# Altium schematic sheet Master_controler.SchDoc
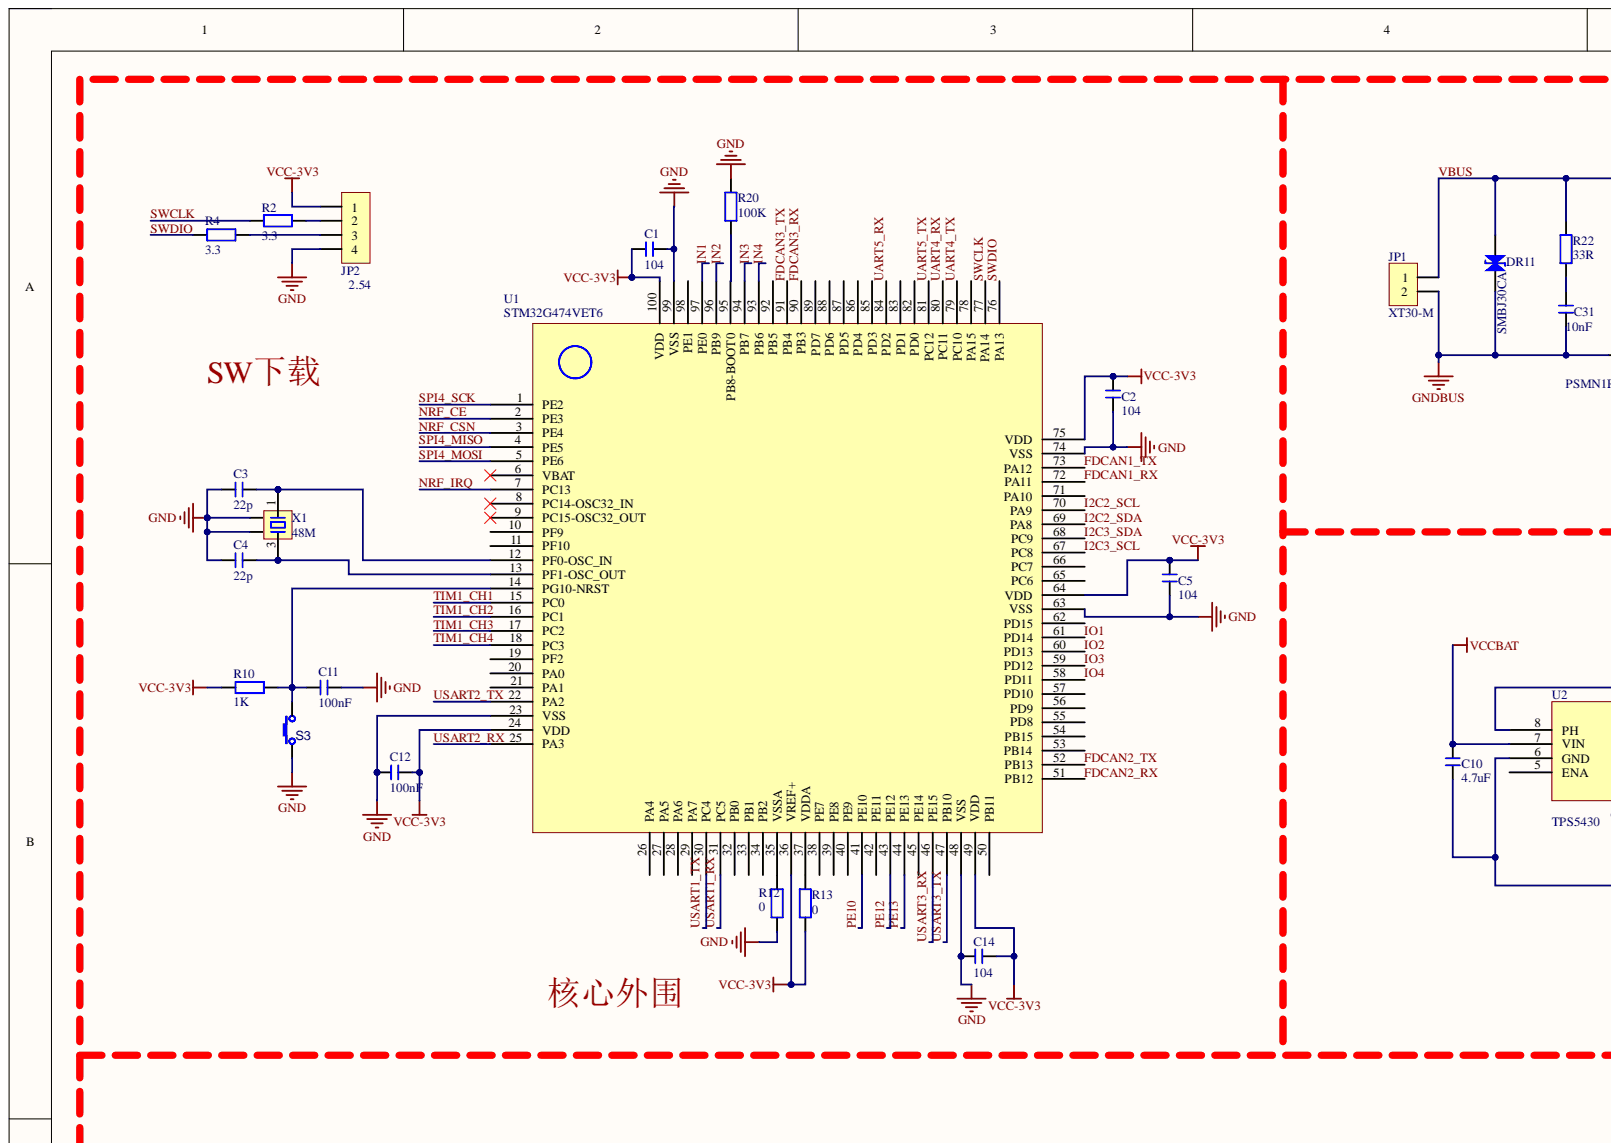
[diagram p0: Master_controler.SchDoc, top left]
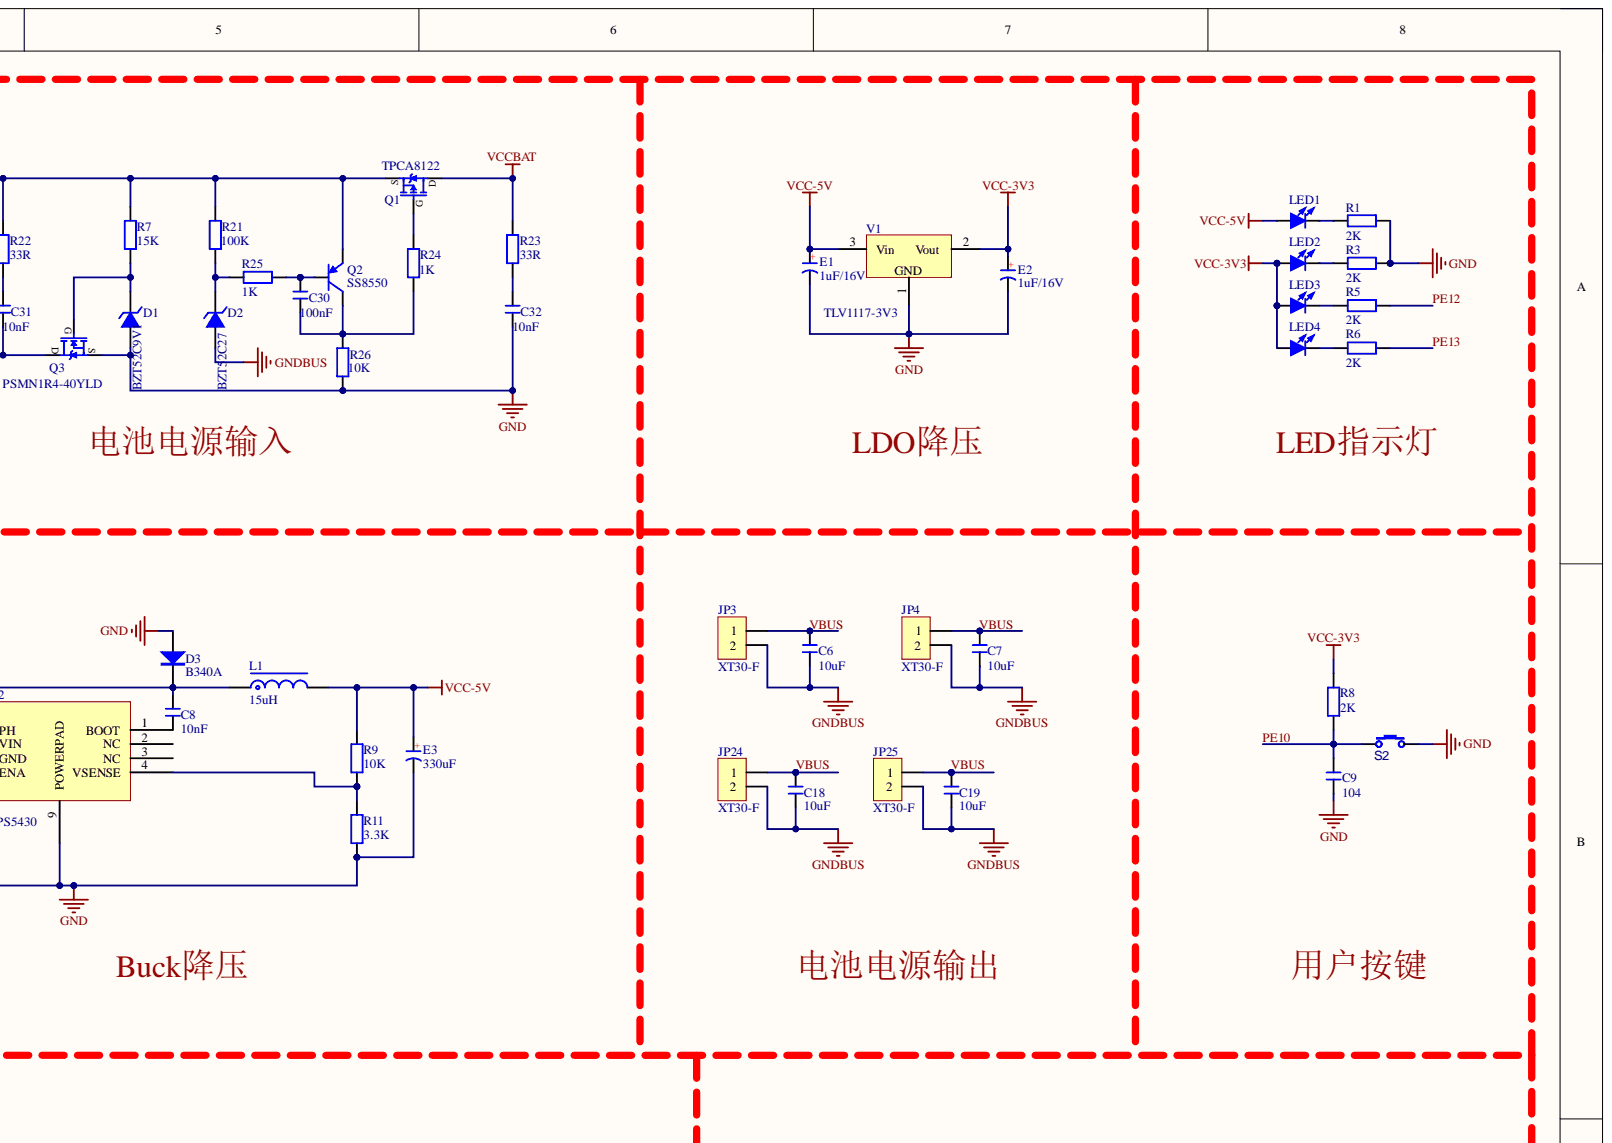
[diagram p1: Master_controler.SchDoc, top right]
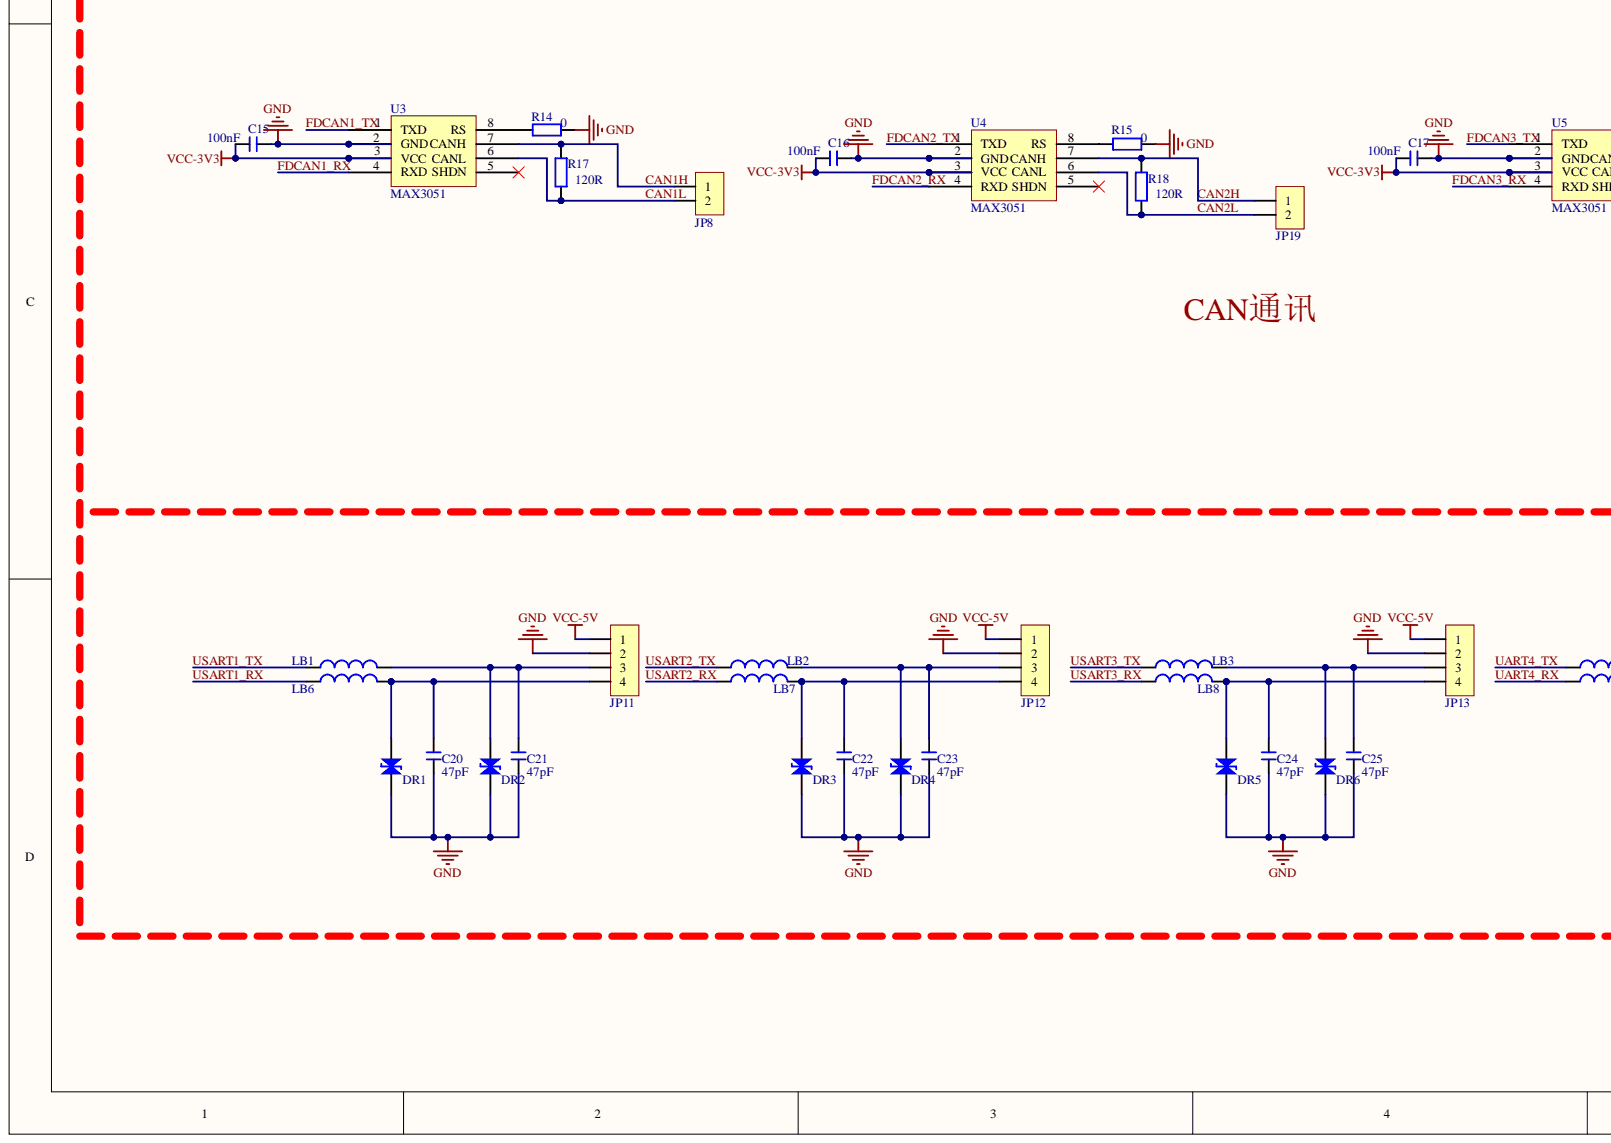
[diagram p2: Master_controler.SchDoc, bottom left]
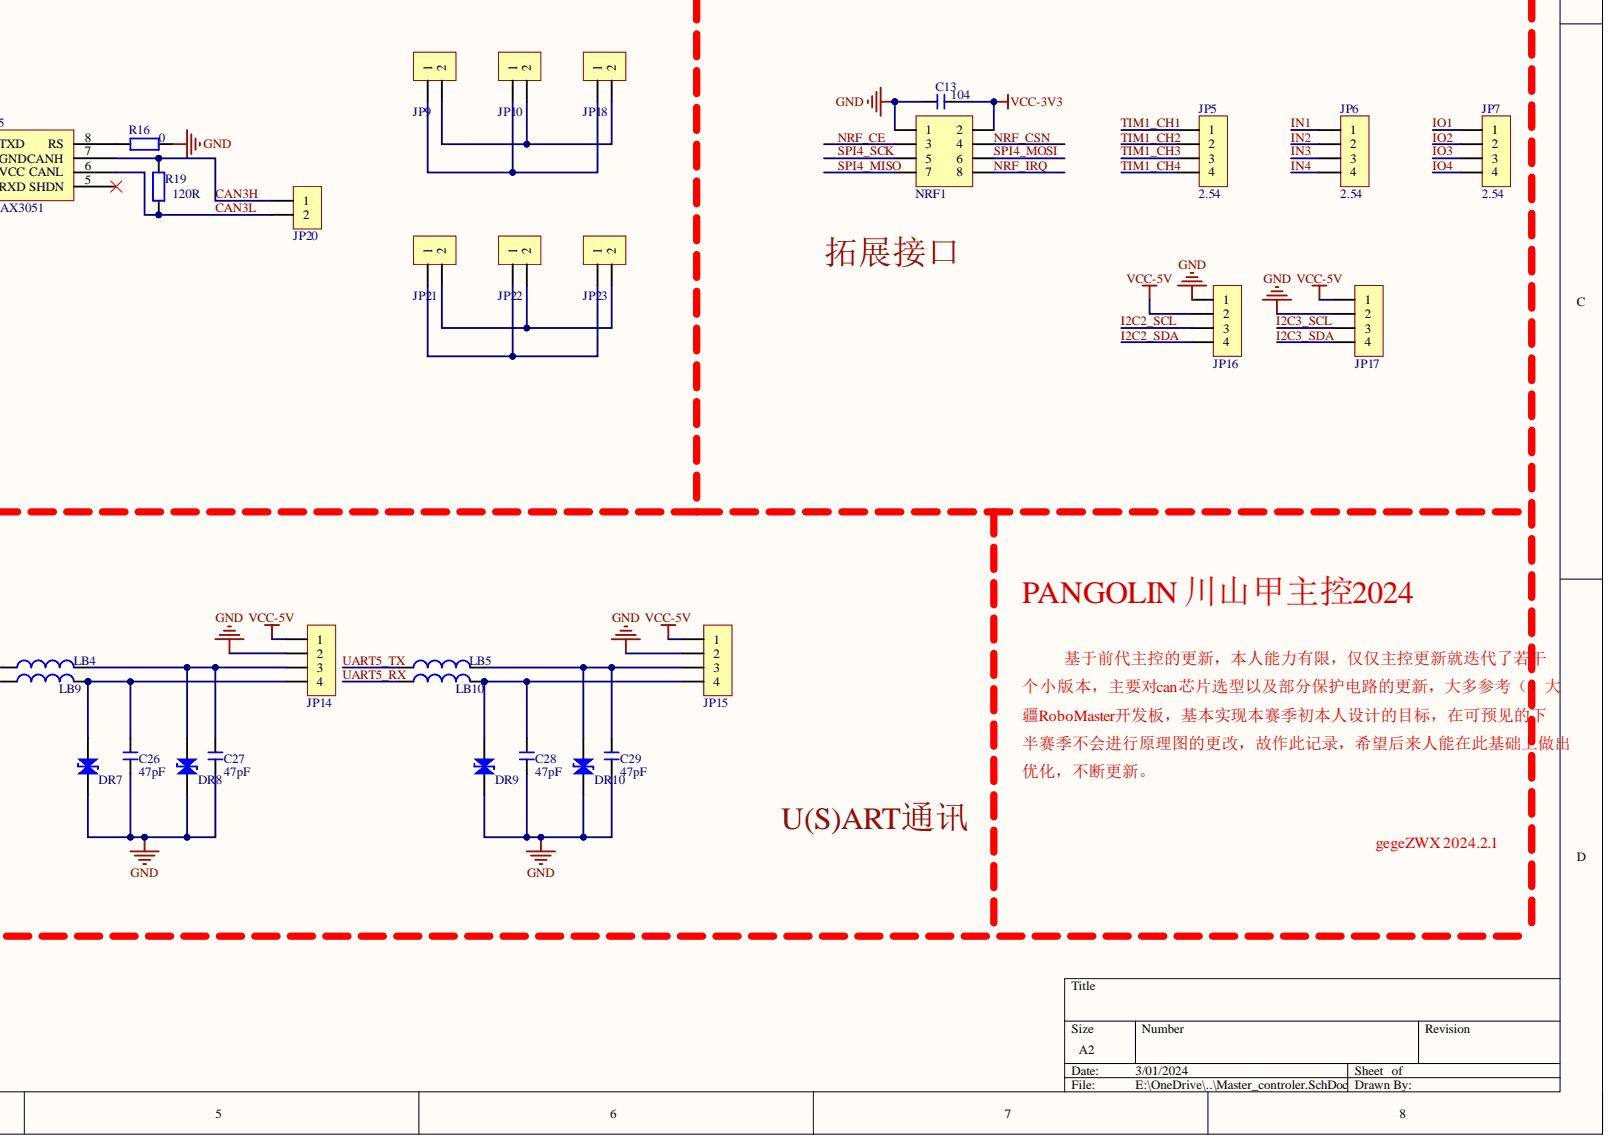
[diagram p3: Master_controler.SchDoc, bottom right]

## Parts
C1  104  [C 0603_M]
C2  104  [C 0603_M]
C3  22p  [C 0603_M]
C4  22p  [C 0603_M]
C5  104  [C 0603_M]
C6  10uF  [C 1206_L]
C7  10uF  [C 1206_L]
C8  10nF  [C 0603_M]
C9  104  [C 0603_M]
C10  4.7uF  [C 0603_M]
C11  100nF  [C 0603_M]
C12  100nF  [C 0603_M]
C13  104  [C 0603_M]
C14  104  [C 0603_M]
C15  100nF  [C 0603_M]
C16  100nF  [C 0603_M]
C17  100nF  [C 0603_M]
C18  10uF  [C 1206_L]
C19  10uF  [C 1206_L]
C20  47pF  [C 0402_M]
C21  47pF  [C 0402_M]
C22  47pF  [C 0402_M]
C23  47pF  [C 0402_M]
C24  47pF  [C 0402_M]
C25  47pF  [C 0402_M]
C26  47pF  [C 0402_M]
C27  47pF  [C 0402_M]
C28  47pF  [C 0402_M]
C29  47pF  [C 0402_M]
C30  100nF  [C 0603_L]
C31  10nF  [C 0603_L]
C32  10nF  [C 0603_L]
D1  BZT52C9V1  [SOD-123]
D2  BZT52C27  [SOD-123]
D3  B340A  [SMA]
DR1  1.5KE18CA  [SOD-523]  (value not drawn)
DR2  1.5KE18CA  [SOD-523]  (value not drawn)
DR3  1.5KE18CA  [SOD-523]  (value not drawn)
DR4  1.5KE18CA  [SOD-523]  (value not drawn)
DR5  1.5KE18CA  [SOD-523]  (value not drawn)
DR6  1.5KE18CA  [SOD-523]  (value not drawn)
DR7  1.5KE18CA  [SOD-523]  (value not drawn)
DR8  1.5KE18CA  [SOD-523]  (value not drawn)
DR9  1.5KE18CA  [SOD-523]  (value not drawn)
DR10  1.5KE18CA  [SOD-523]  (value not drawn)
DR11  SMBJ30CA  [SMB]
E1  1uF/16V  [CD A(3216)]
E2  1uF/16V  [CD A(3216)]
E3  330uF  [CM E(8*10.2)]
JP1  XT30-M  [XT30PW-M]
JP2  2.54  [GH1.25-WT-4P]
JP3  XT30-F  [XT30PB-F]
JP4  XT30-F  [XT30PB-F]
JP5  2.54  [GH1.25-WT-4P]
JP6  2.54  [GH1.25-WT-4P]
JP7  2.54  [GH1.25-WT-4P]
JP8  HDR-1X2  [GH1.25-WT-2P]  (value not drawn)
JP9  HDR-1X2  [GH1.25-WT-2P]  (value not drawn)
JP10  HDR-1X2  [GH1.25-WT-2P]  (value not drawn)
JP11  HDR-1X4  [GH-1.25-4Pin]  (value not drawn)
JP12  HDR-1X4  [GH-1.25-4Pin]  (value not drawn)
JP13  HDR-1X4  [GH-1.25-4Pin]  (value not drawn)
JP14  HDR-1X4  [GH-1.25-4Pin]  (value not drawn)
JP15  HDR-1X4  [GH-1.25-4Pin]  (value not drawn)
JP16  HDR-1X4  [HDR2.54-Y-LI-4P]  (value not drawn)
JP17  HDR-1X4  [GH-1.25-4Pin]  (value not drawn)
JP18  HDR-1X2  [GH1.25-WT-2P]  (value not drawn)
JP19  HDR-1X2  [GH1.25-WT-2P]  (value not drawn)
JP20  HDR-1X2  [GH1.25-WT-2P]  (value not drawn)
JP21  HDR-1X2  [GH1.25-WT-2P]  (value not drawn)
JP22  HDR-1X2  [GH1.25-WT-2P]  (value not drawn)
JP23  HDR-1X2  [GH1.25-WT-2P]  (value not drawn)
JP24  XT30-F  [XT30PB-F]
JP25  XT30-F  [XT30PB-F]
L1  15uH  [CD75]
LB1  500R@100MHz  [FB 0402_M]  (value not drawn)
LB2  500R@100MHz  [FB 0402_M]  (value not drawn)
LB3  500R@100MHz  [FB 0402_M]  (value not drawn)
LB4  500R@100MHz  [FB 0402_M]  (value not drawn)
LB5  500R@100MHz  [FB 0402_M]  (value not drawn)
LB6  500R@100MHz  [FB 0402_M]  (value not drawn)
LB7  500R@100MHz  [FB 0402_M]  (value not drawn)
LB8  500R@100MHz  [FB 0402_M]  (value not drawn)
LB9  500R@100MHz  [FB 0402_M]  (value not drawn)
LB10  500R@100MHz  [FB 0402_M]  (value not drawn)
LED1  红色  [LED 0603G]  (value not drawn)
LED2  红色  [LED 0603G]  (value not drawn)
LED3  红色  [LED 0603G]  (value not drawn)
LED4  红色  [LED 0603G]  (value not drawn)
NRF1  Header 4X2  [HDR2.54-LI-2x4P]  (value not drawn)
Q1  TPCA8122  [DFN 5*6]
Q2  SS8550  [SOT23-3N]
Q3  PSMN1R4-40YLD  [DFN 5*6]
R1  2K  [R 0603_M]
R2  3.3  [R 0603_M]
R3  2K  [R 0603_M]
R4  3.3  [R 0603_M]
R5  2K  [R 0603_M]
R6  2K  [R 0603_M]
R7  15K  [R 0603_L]
R8  2K  [R 0603_M]
R9  10K  [R 0603_M]
R10  1K  [R 0603_M]
R11  3.3K  [R 0603_M]
R12  0  [R 0603_M]
R13  0  [R 0603_M]
R14  0  [R 0603_M]
R15  0  [R 0603_M]
R16  0  [R 0603_M]
R17  120R  [R 0603_M]
R18  120R  [R 0603_M]
R19  120R  [R 0603_M]
R20  100K  [R 0603_M]
R21  100K  [R 0603_L]
R22  33R  [R 0603_L]
R23  33R  [R 0603_L]
R24  1K  [R 0603_L]
R25  1K  [R 0603_L]
R26  10K  [R 0603_L]
S2  Button  [PTS810-3*4-CurvePin]  (value not drawn)
S3  Button  [PTS810-3*4-CurvePin]  (value not drawn)
U1  STM32G474VET6  [LQFP100 14x14_N]
U2  TPS5430  [ESOP8_M]
U3  MAX3051  [SOP8_L]
U4  MAX3051  [SOP8_L]
U5  MAX3051  [SOP8_L]
V1  TLV1117-3V3  [SOT223_N]
X1  48M  [OSC 3225-4P]

## Nets
GND: C1.1 C2.1 C3.1 C4.1 C5.1 C9.1 C10.1 C11.2 C12.2 C13.2 C14.2 C15.1 C16.1 C17.1 C20.1 C21.1 C22.1 C23.1 C24.1 C25.1 C26.1 C27.1 C28.1 C29.1 C32.2 D1.1 D3.1 DR1.1 DR2.1 DR3.1 DR4.1 DR5.1 DR6.1 DR7.1 DR8.1 DR9.1 DR10.1 E1.2 E2.2 E3.2 JP2.4 JP11.2 JP12.2 JP13.2 JP14.2 JP15.2 JP16.1 JP17.2 NRF1.1 Q3.3 R1.1 R3.1 R11.1 R12.1 R14.1 R15.1 R16.1 R20.1 R26.2 S2.1 S3.2 U1.23(VSS) U1.48(VSS) U1.63(VSS) U1.74(VSS) U1.99(VSS) U2.6(GND) U2.9(POWERPAD) U3.2(GND) U4.2(GND) U5.2(GND) V1.1 X1.2 X1.4
GNDBUS: C6.1 C7.1 C18.1 C19.1 C31.2 D2.1 DR11.2 JP1.2 JP3.2 JP4.2 JP24.2 JP25.2 Q3.2
VCC-3V3: C1.2 C2.2 C5.2 C12.1 C13.1 C14.1 C15.2 C16.2 C17.2 E2.1 JP2.1 LED2.1 LED3.1 LED4.1 NRF1.2 R8.1 R10.2 R13.1 U1.24(VDD) U1.36(VREF+) U1.49(VDD) U1.64(VDD) U1.75(VDD) U1.100(VDD) U3.3(VCC) U4.3(VCC) U5.3(VCC) V1.2
VCC-5V: E1.1 E3.1 JP11.1 JP12.1 JP13.1 JP14.1 JP15.1 JP16.2 JP17.1 L1.2 LED1.1 R9.2 V1.3
VCCBAT: C10.2 Q1.2 R23.1 U2.7(VIN)
CAN1H: JP8.1 R17.1 U3.7(CANH)
CAN1L: JP8.2 R17.2 U3.6(CANL)
CAN2H: JP19.1 R18.1 U4.7(CANH)
CAN2L: JP19.2 R18.2 U4.6(CANL)
CAN3H: JP20.1 R19.1 U5.7(CANH)
CAN3L: JP20.2 R19.2 U5.6(CANL)
FDCAN1_RX: U1.72(PA11) U3.4(RXD)
FDCAN1_TX: U1.73(PA12) U3.1(TXD)
FDCAN2_RX: U1.51(PB12) U4.4(RXD)
FDCAN2_TX: U1.52(PB13) U4.1(TXD)
FDCAN3_RX: U1.90(PB3) U5.4(RXD)
FDCAN3_TX: U1.91(PB4) U5.1(TXD)
I2C2_SCL: JP16.3 U1.70(PA9)
I2C2_SDA: JP16.4 U1.69(PA8)
I2C3_SCL: JP17.3 U1.67(PC8)
I2C3_SDA: JP17.4 U1.68(PC9)
IN1: JP6.1 U1.97(PE0)
IN2: JP6.2 U1.96(PB9)
IN3: JP6.3 U1.94(PB7)
IN4: JP6.4 U1.93(PB6)
IO1: JP7.1 U1.61(PD14)
IO2: JP7.2 U1.60(PD13)
IO3: JP7.3 U1.59(PD12)
IO4: JP7.4 U1.58(PD11)
NRF_CE: NRF1.3 U1.2(PE3)
NRF_CSN: NRF1.4 U1.3(PE4)
NRF_IRQ: NRF1.8 U1.7(PC13)
PE10: C9.2 R8.2 S2.2 U1.41(PE10)
PE12: R5.1 U1.43(PE12)
PE13: R6.1 U1.44(PE13)
SPI4_MISO: NRF1.7 U1.4(PE5)
SPI4_MOSI: NRF1.6 U1.5(PE6)
SPI4_SCK: NRF1.5 U1.1(PE2)
SWCLK: R2.2 U1.77(PA14)
SWDIO: R4.2 U1.76(PA13)
TIM1_CH1: JP5.1 U1.15(PC0)
TIM1_CH2: JP5.2 U1.16(PC1)
TIM1_CH3: JP5.3 U1.17(PC2)
TIM1_CH4: JP5.4 U1.18(PC3)
UART4_RX: LB9.1 U1.80(PC11)
UART4_TX: LB4.1 U1.79(PC10)
UART5_RX: LB10.1 U1.84(PD2)
UART5_TX: LB5.1 U1.81(PC12)
USART1_RX: LB6.1 U1.31(PC5)
USART1_TX: LB1.1 U1.30(PC4)
USART2_RX: LB7.1 U1.25(PA3)
USART2_TX: LB2.1 U1.22(PA2)
USART3_RX: LB8.1 U1.46(PE15)
USART3_TX: LB3.1 U1.47(PB10)
VBUS: C6.2 C7.2 C18.2 C19.2 DR11.1 JP1.1 JP3.1 JP4.1 JP24.1 JP25.1 Q1.3 Q2.2 R7.1 R21.1 R22.1
NetC3_2: C3.2 U1.12(PF0-OSC_IN) X1.1
NetC4_2: C4.2 U1.13(PF1-OSC_OUT) X1.3
NetC8_1: C8.1 D3.2 L1.1 U2.8(PH)
NetC8_2: C8.2 U2.1(BOOT)
NetC11_1: C11.1 R10.1 S3.1 U1.14(PG10-NRST)
NetC20_2: C20.2 DR1.2 JP11.4 LB6.2
NetC21_2: C21.2 DR2.2 JP11.3 LB1.2
NetC22_2: C22.2 DR3.2 JP12.4 LB7.2
NetC23_2: C23.2 DR4.2 JP12.3 LB2.2
NetC24_2: C24.2 DR5.2 JP13.4 LB8.2
NetC25_2: C25.2 DR6.2 JP13.3 LB3.2
NetC26_2: C26.2 DR7.2 JP14.4 LB9.2
NetC27_2: C27.2 DR8.2 JP14.3 LB4.2
NetC28_2: C28.2 DR9.2 JP15.4 LB10.2
NetC29_2: C29.2 DR10.2 JP15.3 LB5.2
NetC30_1: C30.1 Q2.1 R25.2
NetC30_2: C30.2 Q2.3 R24.2 R26.1
NetC31_1: C31.1 R22.2
NetC32_1: C32.1 R23.2
NetD1_2: D1.2 Q3.1 R7.2
NetD2_2: D2.2 R21.2 R25.1
NetJP2_2: JP2.2 R2.1
NetJP2_3: JP2.3 R4.1
NetJP9_1: JP9.1 JP10.1 JP18.1
NetJP9_2: JP9.2 JP10.2 JP18.2
NetJP21_1: JP21.1 JP22.1 JP23.1
NetJP21_2: JP21.2 JP22.2 JP23.2
NetLED1_2: LED1.2 R1.2
NetLED2_2: LED2.2 R3.2
NetLED3_2: LED3.2 R5.2
NetLED4_2: LED4.2 R6.2
NetQ1_1: Q1.1 R24.1
NetR9_1: R9.1 R11.2 U2.4(VSENSE)
NetR12_2: R12.2 U1.35(VSSA)
NetR13_2: R13.2 U1.37(VDDA)
NetR14_2: R14.2 U3.8(RS)
NetR15_2: R15.2 U4.8(RS)
NetR16_2: R16.2 U5.8(RS)
NetR20_2: R20.2 U1.95(PB8-BOOT0)

Not connected: U1 6(VBAT) 8(PC14-OSC32_IN) 9(PC15-OSC32_OUT) 10(PF9) 11(PF10) 19(PF2) 20(PA0) 21(PA1) 26(PA4) 27(PA5) 28(PA6) 29(PA7) 32(PB0) 33(PB1) 34(PB2) 38(PE7) 39(PE8) 40(PE9) 42(PE11) 45(PE14) 50(PB11) 53(PB14) 54(PB15) 55(PD8) 56(PD9) 57(PD10) 62(PD15) 65(PC6) 66(PC7) 71(PA10) 78(PA15) 82(PD0) 83(PD1) 85(PD3) 86(PD4) 87(PD5) 88(PD6) 89(PD7) 92(PB5) 98(PE1); U2 2(NC) 3(NC) 5(ENA); U3 5(SHDN); U4 5(SHDN); U5 5(SHDN)

## Library data of the parts
C1-C32 = C: 无极性贴片电容; 材质: X7R; BOM名称: 无极性贴片电容; Component Kind: Standard; Library Name: 3.1   - 电阻-电容-电感.SchLib
D1 D2 = 00_稳压二极管: 以BZT52Cxx为代表的稳压二极管; Component Kind: Standard; Library Name: 8.1   - 二极管-整流桥.SchLib
D3 = M7: 整流二极管; BOM名称: 整流二极管; Component Kind: Standard; Library Name: 8.1   - 二极管-整流桥.SchLib
DR1-DR10 = DR: 瞬态电压抑制二极管; BOM名称: 瞬态电压抑制二极管; Component Kind: Standard; Library Name: 8.1   - 二极管-整流桥.SchLib
DR11 = 02_TVS瞬态抑制二极管: 瞬态电压抑制二极管; BOM名称: 瞬态电压抑制二极管; Component Kind: Standard; Library Name: 8.1   - 二极管-整流桥.SchLib
E1 E2 = C-CD: 贴片钽电容; BOM名称: 贴片钽电容; Component Kind: Standard; Library Name: 3.1   - 电阻-电容-电感.SchLib
E3 = C-CM: 贴片电解电容; BOM名称: 贴片电解电容; Component Kind: Standard; Library Name: 3.1   - 电阻-电容-电感.SchLib
JP1 = XT30-M: XT30公头; 当前库版本V2023.8.14 @淘宝卖家: 最新版本发布https://item.taobao.com/item.htm?id=566775463719; Component Kind: Standard; Library Name: 4.14 - 电源接头.SchLib
JP2 JP5-JP7 JP11-JP17 = Header 4: 4P接插件; BOM名称: 4P接插件; Component Kind: Standard
  JP2 JP5-JP7: Library Name: 4.6   - 接插件-脚距2.54.SchLib
  JP11-JP17: Library Name: 4.2   - 接插件-脚距1.25.SchLib
JP3 JP4 JP24 JP25 = XT30-F: XT30母座; 当前库版本V2023.8.14 @淘宝卖家: 最新版本发布https://item.taobao.com/item.htm?id=566775463719; Component Kind: Standard; Library Name: 4.14 - 电源接头.SchLib
JP8-JP10 JP18-JP23 = Header 2: 2P接插件; BOM名称: 2P接插件; Component Kind: Standard; Library Name: 4.2   - 接插件-脚距1.25.SchLib
L1 = L-CD: 贴片功率电感; BOM名称: 功率电感; Component Kind: Standard; Library Name: 3.1   - 电阻-电容-电感.SchLib
LB1-LB10 = LB: 磁珠; BOM名称: 磁珠; Component Kind: Standard; Library Name: 3.1   - 电阻-电容-电感.SchLib
LED1-LED4 = LED-SMD: 贴片LED; BOM名称: 贴片LED; Component Kind: Standard; Library Name: 9.1   - LED.SchLib
NRF1 = Header 4X2: 4*2P接插件; BOM名称: 4*2P接插件; Component Kind: Standard; Library Name: 4.6   - 接插件-脚距2.54.SchLib
Q1 = 03 P-MOS: P沟道 TO252 TO220; BOM名称: 场效应管; 描述: P沟道 50V/15A; Component Kind: Standard; Library Name: 6.1   - 场效应管-可控硅.SchLib
Q2 = 8550-SMD: 高频放大-PNP型; 属性: 40V 1.5A 1W 100MHZ; BOM名称: 高频放大-PNP型; Component Kind: Standard; Library Name: 6.2   - 三极管.SchLib
Q3 = 00 N-MOS1: N沟道 TO252 TO220; BOM名称: 场效应管; 描述: N沟道 60V/12A; Component Kind: Standard; Library Name: 6.1   - 场效应管-可控硅.SchLib
R1-R26 = R: 贴片电阻; BOM名称: 贴片电阻; Component Kind: Standard; Library Name: 3.1   - 电阻-电容-电感.SchLib
S2 S3 = KEY: 按键; Component Kind: Standard; Library Name: 5.1   - 按键-开关.SchLib; Price(RMB): 0.000; Published: 24-Mar-1999; Publisher: Altium Limited
U3-U5 = MAX3051: CAN收发芯片  美信  ESA+T; Component Kind: Standard; Library Name: 1.1   - IC芯片.SchLib
V1 = AMS1117: 三端稳压芯片; BOM名称: 稳压芯片; Component Kind: Standard; Library Name: 1.3   - 电源类芯片.SchLib
X1 = XTAL-4P: 4脚无源晶振; 负载电容: CL20pF; BOM名称: 4脚无源晶振; Component Kind: Standard; Library Name: 10.1 - 保险丝-晶振.SchLib
U1 = STM32G474VET6: Component Kind: Standard; Library Name: STM32G474VET6.SchLib
U2 = TPS5430: Component Kind: Standard; Library Name: STM32G474VET6.SchLib
